# Altium schematic sheet Power Stage.SchDoc
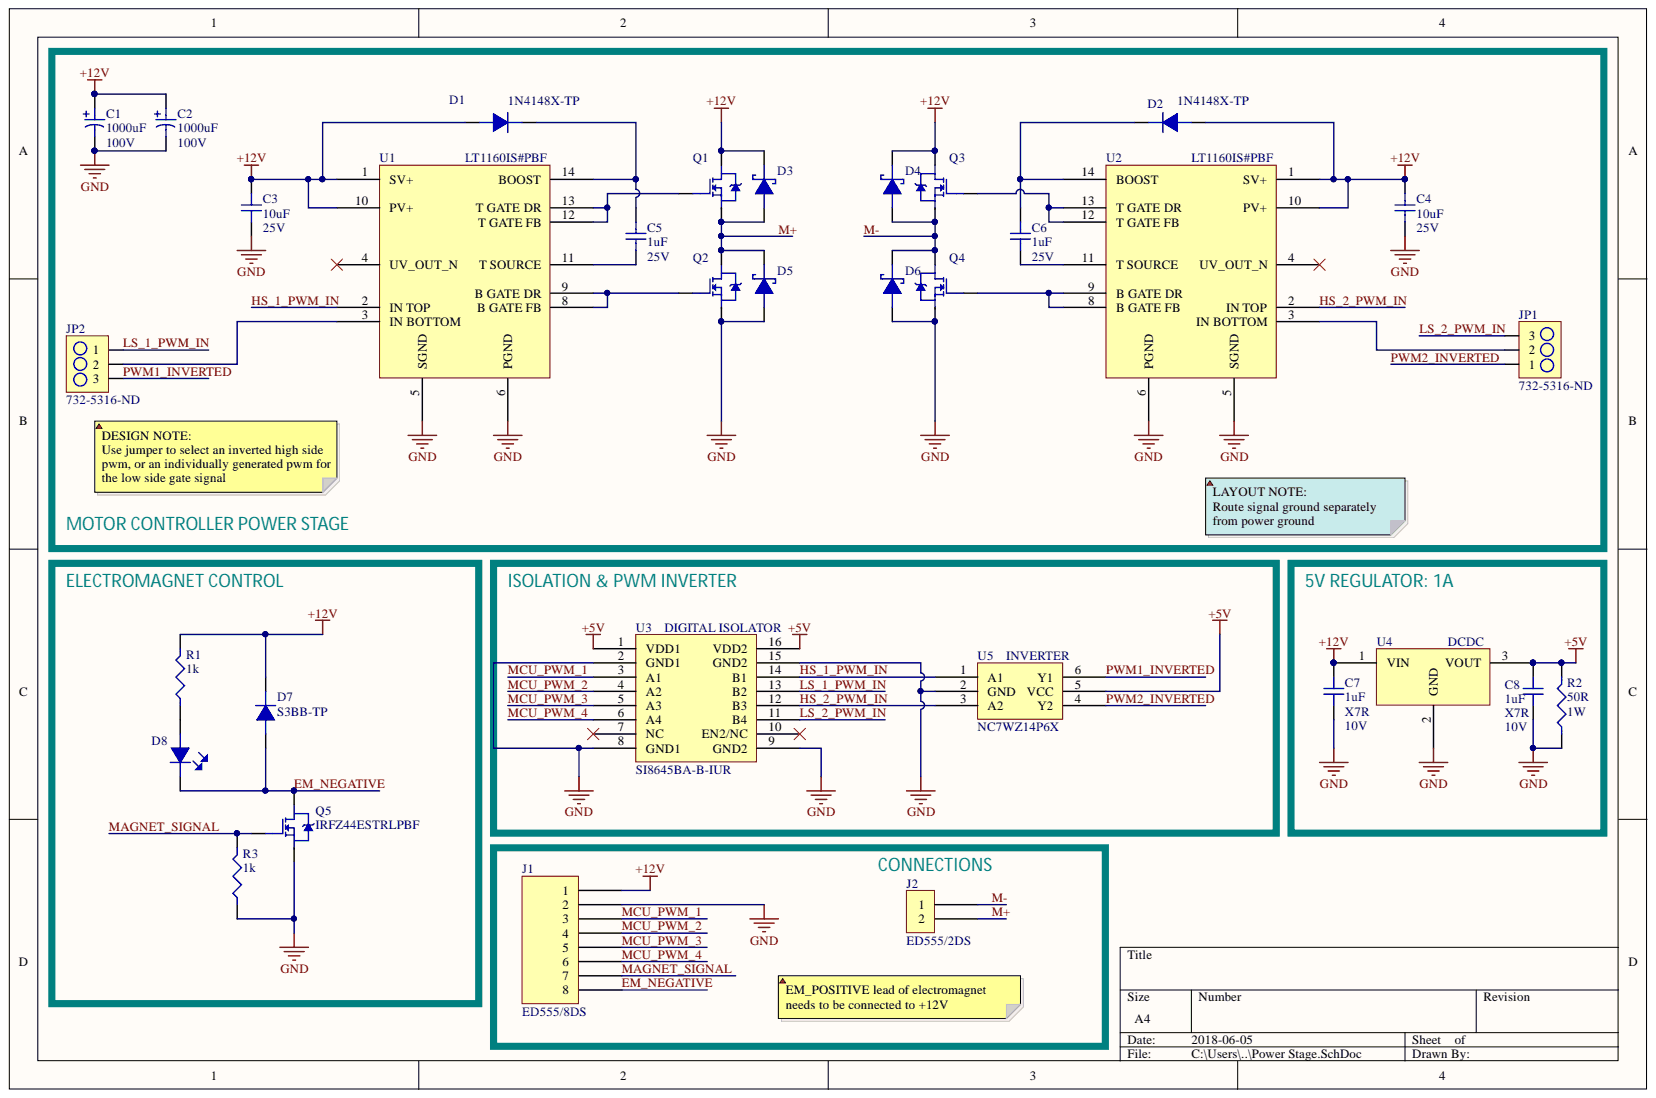
[diagram p0: Power Stage.SchDoc]

## Parts
C1  Capacitance: 1000uF  Voltage Rating: 100V  [CAP_THRU]  (hidden comment: UVR2A102MRD6)
C2  Capacitance: 1000uF  Voltage Rating: 100V  [CAP_THRU]  (hidden comment: UVR2A102MRD6)
C3  Capacitance: 10uF  Voltage Rating: 25V  [C1206]  (hidden comment: C3216X5R1E106K160AB)
C4  Capacitance: 10uF  Voltage Rating: 25V  [C1206]  (hidden comment: C3216X5R1E106K160AB)
C5  1uF  Voltage: 25V  [C0805]
C6  1uF  Voltage: 25V  [C0805]
C7  1uF  Dielectric: X7R  Voltage: 10V  [C0805]
C8  1uF  Dielectric: X7R  Voltage: 10V  [C0805]
D1  1N4148X-TP  [SOD523]
D2  1N4148X-TP  [SOD523]
D3  CDBA540-HF  [DO214-AC]  (value not drawn)
D4  CDBA540-HF  [DO214-AC]  (value not drawn)
D5  CDBA540-HF  [DO214-AC]  (value not drawn)
D6  CDBA540-HF  [DO214-AC]  (value not drawn)
D7  S3BB-TP  [DO-214AA_Diode]
D8  TST-C191TBKT-5A  [LED_0603]  (value not drawn)
J1  ED555/8DS  [8POS_CONNECTOR]
J2  ED555/2DS  [2POS_CONNECTOR]
JP1  732-5316-ND  [3PIN_HEADER]
JP2  732-5316-ND  [3PIN_HEADER]
Q1  IRFZ44ESTRLPBF  [D2PAK]
Q2  IRFZ44ESTRLPBF  [D2PAK]
Q3  IRFZ44ESTRLPBF  [D2PAK]
Q4  IRFZ44ESTRLPBF  [D2PAK]
Q5  IRFZ44ESTRLPBF  [D2PAK]
R1  1k  [R0805]
R2  50R  Max Power Dissipation: 1W  [R2512]
R3  1k  [R0805]
U1  LT1160IS#PBF  [SOIC-14]
U2  LT1160IS#PBF  [SOIC-14]
U3  SI8645BA-B-IUR  [QSOP-16]
U4  DCDC  [DCDC]
U5  NC7WZ14P6X  [TSOP-6]

## Nets
+5V: C8.2 R2.2 U3.1(VDD1) U3.16(VDD2) U4.3 U5.5(VCC)
+12V: C1.1 C2.1 C3.2 C4.2 C7.2 D1.1 D2.1 D3.2 D4.2 D7.2 J1.1 Q1.2 Q3.2 R1.2 U1.1(SV+) U1.10(PV+) U2.1(SV+) U2.10(PV+) U4.1
GND: C1.2 C2.2 C3.1 C4.1 C7.1 C8.1 D5.1 D6.1 J1.2 Q2.3 Q4.3 Q5.3 R2.1 R3.1 U1.5(SGND) U1.6(PGND) U2.5(SGND) U2.6(PGND) U3.2(GND1) U3.8(GND1) U3.9(GND2) U3.15(GND2) U4.2 U5.2(GND)
EM_NEGATIVE: D7.1 D8.2 J1.8 Q5.2
HS_1_PWM_IN: U1.2(IN_TOP) U3.14(B1) U5.1(A1)
HS_2_PWM_IN: U2.2(IN_TOP) U3.12(B3) U5.3(A2)
LS_1_PWM_IN: JP2.1 U3.13(B2)
LS_2_PWM_IN: JP1.3 U3.11(B4)
M+: D3.1 D5.2 J2.2 Q1.3 Q2.2
M-: D4.1 D6.2 J2.1 Q3.3 Q4.2
MAGNET_SIGNAL: J1.7 Q5.1 R3.2
MCU_PWM_1: J1.3 U3.3(A1)
MCU_PWM_2: J1.4 U3.4(A2)
MCU_PWM_3: J1.5 U3.5(A3)
MCU_PWM_4: J1.6 U3.6(A4)
PWM1_INVERTED: JP2.3 U5.6(Y1)
PWM2_INVERTED: JP1.1 U5.4(Y2)
NetC5_1: C5.1 U1.11(T_SOURCE)
NetC5_2: C5.2 D1.2 U1.14(BOOST)
NetC6_1: C6.1 U2.11(T_SOURCE)
NetC6_2: C6.2 D2.2 U2.14(BOOST)
NetD8_1: D8.1 R1.1
NetJP1_2: JP1.2 U2.3(IN_BOTTOM)
NetJP2_2: JP2.2 U1.3(IN_BOTTOM)
NetQ1_1: Q1.1 U1.12(T_GATE_FB) U1.13(T_GATE_DR)
NetQ2_1: Q2.1 U1.8(B_GATE_FB) U1.9(B_GATE_DR)
NetQ3_1: Q3.1 U2.12(T_GATE_FB) U2.13(T_GATE_DR)
NetQ4_1: Q4.1 U2.8(B_GATE_FB) U2.9(B_GATE_DR)

Not connected: U1 4(UV_OUT_N); U2 4(UV_OUT_N); U3 7(NC) 10(EN2/NC)

## Library data of the parts
C1 C2 = UVR2A102MRD6: CAP Electrolytic 1000uF 100V; Manufacturer: Nichicon; Dissipation Factor: 0.08%; Lead/Base Style: Straight; Life (Hours): 2000Hour; Polarity: Polar; Ripple Current: 1.38A; Tolerance: 20%; Case/Package: Radial; Component Kind: Standard; ComponentLink1Description: http://www.mouser.com/ds/2/293/e-uvr-1076495.pdf; ComponentLink1URL: http://www.mouser.com/ds/2/293/e-uvr-1076495.pdf; ComponentLink2Description: http://nichicon-us.com/english/products/pdfs/e-uvr.pdf; ComponentLink2URL: http://nichicon-us.com/english/products/pdfs/e-uvr.pdf; Lead Pitch: 7.5mm; Length: 35mm; Library Name: SCH_SYMBOLS.SchLib; Manufacturer Part Number: UVR2A102MRD6; Max Operating Temperature: 85°C; Min Operating Temperature: -40°C; Mount: Through Hole; Package Quantity: 50; Packaging: Bulk; Pricing 1: 1=1.84, 10=1.492, 100=1.1391, 500=0.8679, 1000=0.7594, 2500=0.7323, 5000=0.7052 (USD); Stock 1: 1438; Supplier 1: Digi-Key; Supplier Part Number 1: 493-14310-ND; Termination: Radial; Voltage Rating (DC): 100V
C3 C4 = C3216X5R1E106K160AB: CAP MLCC 10µF ±10% 25V X5R Ceramic Capacitor -55°C ~ 85°C Surface Mount, MLCC 1206 (3216 Metric) 0.126" L x 0.063" W (3.20mm x 1.60mm); Manufacturer: TDK; Case/Package: 1206; Case Code (Imperial): 1206; Case Code (Metric): 3216; Component Kind: Standard; ComponentLink1Description: https://datasheet.ciiva.com/6276/tdkmlcccaprange-6276583.pdf; ComponentLink1URL: https://datasheet.ciiva.com/6276/tdkmlcccaprange-6276583.pdf; ComponentLink2Description: https://datasheet.ciiva.com/17487/e412-c-17487288.pdf; ComponentLink2URL: https://datasheet.ciiva.com/17487/e412-c-17487288.pdf; ComponentLink3Description: https://datasheet.ciiva.com/24217/c3216x5r1e106k160ab-265309-24217784.pdf; ComponentLink3URL: https://datasheet.ciiva.com/24217/c3216x5r1e106k160ab-265309-24217784.pdf; ComponentLink4Description: https://datasheet.ciiva.com/6276/tdkmlcccaprange-6276585.pdf; ComponentLink4URL: https://datasheet.ciiva.com/6276/tdkmlcccaprange-6276585.pdf; ComponentLink5Description: https://datasheet.ciiva.com/6276/tdkmlcccaprange-6276587.pdf; ComponentLink5URL: https://datasheet.ciiva.com/6276/tdkmlcccaprange-6276587.pdf; Composition: Ceramic; Depth: 1.6mm; Dielectric: X5R; Dielectric Material: Ceramic; Height: 1.6mm; Lead Free: Lead Free; Length: 3.2mm; Library Name: SCH_SYMBOLS.SchLib; Manufacturer Part Number: C3216X5R1E106K160AB; Max Operating Temperature: 85°C; Min Operating Temperature: -55°C; Mount: Surface Mount; Number of Pins: 2; Package Quantity: 2000; Packaging: Tape and Reel; Pricing 1: 1=0.83, 10=0.589, 100=0.3881, 500=0.2875, 1000=0.2444 (USD); Radiation Hardening: No; Stock 1: 3130; Supplier 1: Digi-Key; Supplier Part Number 1: 445-6862-1-ND; Termination: SMD/SMT; Thickness: 0.075inch; Tolerance: 10%; Voltage Rating (DC): 25V; Weight: 0.000571oz; Width: 0.063inch
C5 C6 = GCM21BR71E105KA56L: CAP MLCC 1UF 25V X7R 0805; Manufacturer: Murata; Tolerance: 10%; Capacitance: 1uF; Case/Package: 0805; Case Code (Imperial): 0805; Case Code (Metric): 2012; Component Kind: Standard; ComponentLink1Description: https://datasheet.ciiva.com/137/product-137200.pdf; ComponentLink1URL: https://datasheet.ciiva.com/137/product-137200.pdf; ComponentLink2Description: https://datasheet.ciiva.com/3778/359gcm21br71e105ka5623-3778560.pdf; ComponentLink2URL: https://datasheet.ciiva.com/3778/359gcm21br71e105ka5623-3778560.pdf; ComponentLink3Description: https://datasheet.ciiva.com/16624/product-16624576.pdf; ComponentLink3URL: https://datasheet.ciiva.com/16624/product-16624576.pdf; ComponentLink4Description: https://datasheet.ciiva.com/18183/getdatasheetpartid-153106-18183696.pdf; ComponentLink4URL: https://datasheet.ciiva.com/18183/getdatasheetpartid-153106-18183696.pdf; ComponentLink5Description: https://datasheet.ciiva.com/137/product-137201.pdf; ComponentLink5URL: https://datasheet.ciiva.com/137/product-137201.pdf; ComponentLink6Description: https://datasheet.ciiva.com/11789/0900766b80ef92d0-11789092.pdf; ComponentLink6URL: https://datasheet.ciiva.com/11789/0900766b80ef92d0-11789092.pdf; ComponentLink7Description: https://datasheet.ciiva.com/2989/product-2989245.pdf; ComponentLink7URL: https://datasheet.ciiva.com/2989/product-2989245.pdf; ComponentLink8Description: https://datasheet.ciiva.com/2989/product-2989246.pdf; ComponentLink8URL: https://datasheet.ciiva.com/2989/product-2989246.pdf; ComponentLink9Description: https://datasheet.ciiva.com/137/product-137199.pdf; ComponentLink9URL: https://datasheet.ciiva.com/137/product-137199.pdf; Depth: 1.25mm; Dielectric: X7R; Height: 1.25mm; Lead Free: Lead Free; Length: 2mm; Library Name: SCH_SYMBOLS.SchLib; Manufacturer Part Number: GCM21BR71E105KA56L; Max Operating Temperature: 125°C; Min Operating Temperature: -55°C; Mount: Surface Mount; Package Quantity: 3000; Packaging: Tape and Reel; Pricing 1: 1=0.25, 10=0.171, 100=0.0865, 500=0.0592, 1000=0.0524 (USD); Radiation Hardening: No; REACH SVHC: No SVHC; Stock 1: 30000; Supplier 1: Digi-Key; Supplier Part Number 1: 490-4785-1-ND; Termination: SMD/SMT; Thickness: 0.055inch; Voltage Rating: 25V; Voltage Rating (DC): 25V; Width: 0.049inch
C7 C8 = 0805ZC105KAT2A: CAP CER 1UF 10V X7R 0805; Manufacturer: Kyocera AVX; Dissipation Factor: 5%; Insulation Resistance: 100GR; Tolerance: 10%; Capacitance: 1uF; Case/Package: 0805; Case Code (Imperial): 0805; Case Code (Metric): 2012; Component Kind: Standard; ComponentLink1Description: https://datasheet.ciiva.com/2988/cx7r-2988071.pdf; ComponentLink1URL: https://datasheet.ciiva.com/2988/cx7r-2988071.pdf; ComponentLink2Description: https://datasheet.ciiva.com/2988/cx7r-2988072.pdf; ComponentLink2URL: https://datasheet.ciiva.com/2988/cx7r-2988072.pdf; ComponentLink3Description: https://datasheet.ciiva.com/14601/70001075-14601048.pdf; ComponentLink3URL: https://datasheet.ciiva.com/14601/70001075-14601048.pdf; ComponentLink4Description: https://datasheet.ciiva.com/14594/70001075-14594329.pdf; ComponentLink4URL: https://datasheet.ciiva.com/14594/70001075-14594329.pdf; ComponentLink5Description: https://datasheet.ciiva.com/25901/cx7r-25901193.pdf; ComponentLink5URL: https://datasheet.ciiva.com/25901/cx7r-25901193.pdf; ComponentLink6Description: https://datasheet.ciiva.com/15165/getdatasheetpartid-71567-15165451.pdf; ComponentLink6URL: https://datasheet.ciiva.com/15165/getdatasheetpartid-71567-15165451.pdf; ComponentLink7Description: https://datasheet.ciiva.com/151/cx7r-151916.pdf; ComponentLink7URL: https://datasheet.ciiva.com/151/cx7r-151916.pdf; ComponentLink8Description: https://datasheet.ciiva.com/151/cx7r-151917.pdf; ComponentLink8URL: https://datasheet.ciiva.com/151/cx7r-151917.pdf; ComponentLink9Description: https://datasheet.ciiva.com/151/cx7r-151918.pdf; ComponentLink9URL: https://datasheet.ciiva.com/151/cx7r-151918.pdf; ComponentLink10Description: https://datasheet.ciiva.com/11787/0900766b80ed4be2-11787102.pdf; ComponentLink10URL: https://datasheet.ciiva.com/11787/0900766b80ed4be2-11787102.pdf; ComponentLink11Description: https://datasheet.ciiva.com/7812/84526-7812223.pdf; ComponentLink11URL: https://datasheet.ciiva.com/7812/84526-7812223.pdf; ComponentLink12Description: https://datasheet.ciiva.com/19475/2011248-19475855.pdf; ComponentLink12URL: https://datasheet.ciiva.com/19475/2011248-19475855.pdf; Contact Plating: Tin,Matte; Depth: 1.25mm; Height: 1.4mm; Lead Free: Lead Free; Length: 2.01mm; Library Name: SCH_SYMBOLS.SchLib; Manufacturer Part Number: 0805ZC105KAT2A; Max Operating Temperature: 125°C; Min Operating Temperature: -55°C; Mount: Surface Mount,PCB; Number of Pins: 2; Package Quantity: 1; Packaging: Tape and Reel; Pricing 1: 1=0.21, 10=0.147, 100=0.069, 500=0.0484, 1000=0.0401 (USD); Radiation Hardening: No; REACH SVHC: No SVHC; Stock 1: 385183; Supplier 1: Digi-Key; Supplier Part Number 1: 478-1405-1-ND; Termination: SMD/SMT; Thickness: 0.055inch; Voltage Rating: 10V; Voltage Rating (DC): 10V; Width: 1.25mm
D1 D2 = 1N4148X-TP: DIODE GEN PURP 75V 150MA SOD523; Manufacturer: MCC; Capacitance: 2pF; Element Configuration: Single; Forward Current: 300mA; Forward Voltage: 1.25V; Max Repetitive Reverse Voltage (Vrrm): 100V; Max Reverse Leakage Current: 1uA; Max Surge Current: 2A; Polarity: Standard; Power Dissipation: 200mW; Recovery Time: 4ns; Average Rectified Current: 150mA; Component Kind: Standard; ComponentLink1Description: https://datasheet.ciiva.com/911/1n4148xsod-523-911686.pdf; ComponentLink1URL: https://datasheet.ciiva.com/911/1n4148xsod-523-911686.pdf; ComponentLink2Description: https://datasheet.ciiva.com/4435/1n4148xsod-523-358-4435190.pdf; ComponentLink2URL: https://datasheet.ciiva.com/4435/1n4148xsod-523-358-4435190.pdf; ComponentLink3Description: https://datasheet.ciiva.com/911/1n4148xsod-523-911687.pdf; ComponentLink3URL: https://datasheet.ciiva.com/911/1n4148xsod-523-911687.pdf; ComponentLink4Description: https://datasheet.ciiva.com/911/1n4148xsod-523-911688.pdf; ComponentLink4URL: https://datasheet.ciiva.com/911/1n4148xsod-523-911688.pdf; ComponentLink5Description: https://datasheet.ciiva.com/16836/1n4148xsod-523-16836299.pdf; ComponentLink5URL: https://datasheet.ciiva.com/16836/1n4148xsod-523-16836299.pdf; Current Rating: 150mA; Lead Free: Lead Free; Library Name: SCH_SYMBOLS.SchLib; Manufacturer Part Number: 1N4148X-TP; Max Operating Temperature: 150°C; Max Reverse Voltage (DC): 75V; Min Operating Temperature: -65°C; Mount: Surface Mount; Number of Pins: 2; Output Current: 300mA; Package Quantity: 1; Packaging: Tape and Reel; Peak Non-Repetitive Surge Current: 2A; Pricing 1: 1=0.14, 10=0.131, 100=0.0856, 500=0.0419, 1000=0.0285 (USD); Radiation Hardening: No; Reverse Recovery Time: 4ns; Stock 1: 978848; Supplier 1: Digi-Key; Supplier Part Number 1: 1N4148XTPMSCT-ND; Voltage Rating (DC): 75V
D3-D6 = CDBA540-HF: DIODE Schottky Diodes & Rectifiers 40V, 5A; Manufacturer: Comchip; Average Rectified Current: 5A; Component Kind: Standard; ComponentLink1Description: http://www.mouser.com/ds/2/80/CDBA520-HF%20Thru134737.%20CDBA5200-HF%20RevB-221146.pdf; ComponentLink1URL: http://www.mouser.com/ds/2/80/CDBA520-HF%20Thru134737.%20CDBA5200-HF%20RevB-221146.pdf; ComponentLink2Description: http://www.comchiptech.com/cms/UserFiles/CDBA540-HF%20Thru505315.%20CDBA5200-HF%20RevC.pdf; ComponentLink2URL: http://www.comchiptech.com/cms/UserFiles/CDBA540-HF%20Thru505315.%20CDBA5200-HF%20RevC.pdf; Library Name: SCH_SYMBOLS.SchLib; Manufacturer Part Number: CDBA540-HF; Max Reverse Voltage (DC): 40V; Mount: Surface Mount; Packaging: Tape and Reel; Pricing 1: 1=0.49, 10=0.381, 100=0.2612, 500=0.1791, 1000=0.1343 (USD); Stock 1: 61079; Supplier 1: Digi-Key; Supplier Part Number 1: 641-1707-1-ND
D7 = S3BB-TP: DIODE GEN PURP 100V 3A DO214AA; Manufacturer: MCC; Element Configuration: Single; Forward Voltage: 1.15V; Max Surge Current: 100A; Peak Reverse Current: 10uA; Average Rectified Current: 3A; Component Kind: Standard; ComponentLink1Description: https://datasheet.ciiva.com/914/s3ab-s3mbsmb-914278.pdf; ComponentLink1URL: https://datasheet.ciiva.com/914/s3ab-s3mbsmb-914278.pdf; ComponentLink2Description: https://datasheet.ciiva.com/914/s3ab-s3mbsmb-914279.pdf; ComponentLink2URL: https://datasheet.ciiva.com/914/s3ab-s3mbsmb-914279.pdf; ComponentLink3Description: https://datasheet.ciiva.com/914/s3ab-s3mbsmb-914280.pdf; ComponentLink3URL: https://datasheet.ciiva.com/914/s3ab-s3mbsmb-914280.pdf; ComponentLink4Description: https://datasheet.ciiva.com/15200/getdatasheetpartid-686538-15200554.pdf; ComponentLink4URL: https://datasheet.ciiva.com/15200/getdatasheetpartid-686538-15200554.pdf; ComponentLink5Description: https://datasheet.ciiva.com/17437/s3ab-s3mbsmb-17437836.pdf; ComponentLink5URL: https://datasheet.ciiva.com/17437/s3ab-s3mbsmb-17437836.pdf; Lead Free: Lead Free; Library Name: SCH_SYMBOLS.SchLib; Manufacturer Part Number: S3BB-TP; Max Repetitive Reverse Voltage (Vrrm): 100V; Mount: Surface Mount; Number of Pins: 2; Package Quantity: 1; Packaging: Tape and Reel; Peak Non-Repetitive Surge Current: 100A; Pricing 1: 1=0.56, 10=0.441, 25=0.372, 100=0.3028, 250=0.2509, 500=0.2076, 1000=0.1557 (USD); Radiation Hardening: No; Reverse Voltage (DC): 100V; Stock 1: 10943; Supplier 1: Digi-Key; Supplier Part Number 1: S3BB-TPMSCT-ND
D8 = LTST-C191TBKT-5A: LED BLUE CLEAR 0603 SMD; Manufacturer: Vishay Lite-On; Dominant Wavelength: 473nm; Forward Current: 20mA; Forward Voltage: 2.8V; Lens Colour: Colorless; Lens Style: Diffused,Colorless,Rectangular; Luminous Intensity: 15mcd; Number of LEDs: 1; Test Current: 5mA; Viewing Angle: 130°; Voltage: 29V; Case/Package: 0603; Case Code (Imperial): 0603; Case Code (Metric): 1608; Colour: Blue; Component Kind: Standard; ComponentLink1Description: http://components.arrow.com/part/dataview/52218576S9813001N1331; ComponentLink1URL: http://components.arrow.com/part/dataview/52218576S9813001N1331; ComponentLink2Description: http://optoelectronics.liteon.com/zh-cn/api/DwonloadFileHandler.ashx?txtSpecNo=DS22-2003-020&txtPartNo=LTST-C191TBKT-5A; ComponentLink2URL: http://optoelectronics.liteon.com/zh-cn/api/DwonloadFileHandler.ashx?txtSpecNo=DS22-2003-020&txtPartNo=LTST-C191TBKT-5A; Height: 0.55mm; Illumination Colour: Blue; Length: 1.6mm; Lens Transparency: Clear; Library Name: SCH_SYMBOLS.SchLib; Manufacturer Part Number: LTST-C191TBKT-5A; Max Operating Temperature: 80°C; Min Operating Temperature: -20°C; Mount: Surface Mount; Package Quantity: 5000; Packaging: Tape and Reel; Pricing 1: 1=0.52, 10=0.376, 25=0.2884, 100=0.1881, 250=0.138, 500=0.117, 1000=0.0961, 2500=0.0836 (USD); Radiation Hardening: No; Stock 1: 2918; Supplier 1: Digi-Key; Supplier Part Number 1: 160-2212-6-ND; Width: 0.8mm
J1 = ED555/8DS: TERMINAL BLOCK 3.5MM 8POS PCB; Manufacturer: On-Shore Technology; Current: 6A; Number of Levels: 1; Number of Positions: 8; Pitch: 0.138inch; Plating: Steel; Voltage: 150V; Wire Gauge (Max): 28AWG; Wire Gauge (Min): 16AWG; Colour: Grey; Component Kind: Standard; ComponentLink1Description: https://datasheet.ciiva.com/823/ed555ds-823663.pdf; ComponentLink1URL: https://datasheet.ciiva.com/823/ed555ds-823663.pdf; Contact Material: Brass; Contact Plating: Brass; Flammability Rating: UL94 V-0; Height: 8.2mm; Housing Colour: Grey; Housing Material: Nylon; Lead Free: Contains Lead; Length: 28mm; Library Name: SCH_SYMBOLS.SchLib; Manufacturer Part Number: ED555/8DS; Max Operating Temperature: 105°C; Min Operating Temperature: -30°C; Mount: Through Hole; Number of Pins: 8; Number of Positions Per Level: 8; Number of Rows: 1; Packaging: Bulk; Pricing 1: 1=2.74, 10=2.076, 25=1.9244, 50=1.7302, 100=1.688, 250=1.477, 500=1.3504, 1000=1.2238, 5000=1.0761 (USD); Stock 1: 4606; Supplier 1: Digi-Key; Supplier Part Number 1: ED1520-ND; Termination: Screw; Width: 6.2mm
J2 = ED555/2DS: TERMINAL BLOCK 3.5MM 2POS PCB; Manufacturer: On-Shore Technology; Current: 6A; Number of Levels: 1; Number of Positions: 2; Pitch: 0.138inch; Plating: Steel; Voltage: 150V; Wire Gauge (Max): 28AWG; Wire Gauge (Min): 16AWG; Colour: Grey; Component Kind: Standard; ComponentLink1Description: https://datasheet.ciiva.com/823/ed555ds-823583.pdf; ComponentLink1URL: https://datasheet.ciiva.com/823/ed555ds-823583.pdf; Contact Material: Brass; Contact Plating: Brass; Flammability Rating: UL94 V-0; Height: 8.2mm; Housing Colour: Grey; Housing Material: Nylon; Lead Free: Lead Free; Length: 7mm; Library Name: SCH_SYMBOLS.SchLib; Manufacturer Part Number: ED555/2DS; Max Operating Temperature: 105°C; Min Operating Temperature: -30°C; Mount: Through Hole; Number of Pins: 2; Number of Positions Per Level: 2; Number of Rows: 1; Packaging: Bulk; Pricing 1: 1=1.06, 10=0.658, 25=0.5632, 50=0.5064, 100=0.4959, 250=0.422, 500=0.4009, 1000=0.3587, 5000=0.2806 (USD); Stock 1: 38938; Supplier 1: Digi-Key; Supplier Part Number 1: ED1514-ND; Termination: Screw; Width: 6.2mm
JP1 JP2 = 61300311121: CONN_HeaderPins3pos WURTH ELEKTRONIK Board-To-Board Connector, Vertical, WR-PHD Series, Through Hole, Header, 3 Contacts, 2.54 mm; Manufacturer: Wurth Electronics; Connector Type: Male Pin; Gender: Male; Number of Contacts: 3; Pitch: 0.1inch; Case/Package: 100; Colour: Black; Component Kind: Standard; ComponentLink1Description: http://www.farnell.com/cad/1737268.pdf; ComponentLink1URL: http://www.farnell.com/cad/1737268.pdf; Contact Material: Copper; Contact Mating Length: 0.236inch; Contact Plating: Gold; Lead Free: Lead Free; Library Name: SCH_SYMBOLS.SchLib; Manufacturer Part Number: 61300311121; Mount: Through Hole; Number of Positions: 3; Number of Rows: 1; Packaging: Bulk; Pricing 1: 1=0.13, 10=0.106, 25=0.088, 50=0.074, 100=0.067, 1000=0.061 (USD); REACH SVHC: Unknown; Stock 1: 57026; Supplier 1: Digi-Key; Supplier Part Number 1: 732-5316-ND; Termination: Solder
Q1-Q5 = IRFZ44ESTRLPBF: MOSFET N-CH 60V 48A D2PAK; Manufacturer: Infineon; Drain to Source Resistance: 23mR; Gate to Source Voltage (Vgs): 20V; Input Capacitance: 1.36nF; Max Power Dissipation: 110W; Rise Time: 60ns; Turn-Off Delay Time: 70ns; Turn-On Delay Time: 12ns; Case/Package: TO-263-3; Component Kind: Standard; ComponentLink1Description: https://www.alliedelec.com/m/d/1b68331d905676b420cb8944ec9d0bc2.pdf; ComponentLink1URL: https://www.alliedelec.com/m/d/1b68331d905676b420cb8944ec9d0bc2.pdf; ComponentLink2Description: http://www.infineon.com/dgdl/irfz44espbf.pdf?fileId=5546d462533600a40153563b2c662208; ComponentLink2URL: http://www.infineon.com/dgdl/irfz44espbf.pdf?fileId=5546d462533600a40153563b2c662208; ComponentLink3Description: http://www.irf.com/product-info/datasheets/data/irfz44espbf.pdf; ComponentLink3URL: http://www.irf.com/product-info/datasheets/data/irfz44espbf.pdf; Contact Plating: Tin,Matte; Continuous Drain Current (ID): 48A; Drain to Source Breakdown Voltage: 60V; Drain to Source Voltage (Vdss): 60V; Height: 0.19inch; Lead Free: Lead Free; Length: 0.42inch; Library Name: SCH_SYMBOLS.SchLib; Manufacturer Part Number: IRFZ44ESTRLPBF; Max Operating Temperature: 175°C; Min Operating Temperature: -55°C; Mount: Surface Mount; Number of Pins: 3; Packaging: Tape and Reel; Power Dissipation: 110W; Pricing 1: 1=1.61, 10=1.43, 100=1.1304 (USD); Rds On Max: 23mR; Stock 1: 600; Supplier 1: Digi-Key; Supplier Part Number 1: IRFZ44ESTRLPBFCT-ND; Width: 0.38inch
R1 R3 = ERA-6AEB102V: RES SMD 1K OHM 0805 1K Ohm 0.1% 0.125W(1/8W) ±25ppm/C Molded SMD Automotive Punched T/R; Manufacturer: Panasonic ECG; Max Power Dissipation: 125mW; Resistance: 1kR; Temperature Coefficient: 25ppm/°C; Voltage Rating: 100V; Case/Package: 0805; Case Code (Imperial): 0805; Case Code (Metric): 2012; Component Kind: Standard; ComponentLink1Description: https://datasheet.ciiva.com/11811/0900766b80beefcc-11811155.pdf; ComponentLink1URL: https://datasheet.ciiva.com/11811/0900766b80beefcc-11811155.pdf; ComponentLink2Description: https://datasheet.ciiva.com/30057/54aoa0000ce26-30057591.pdf; ComponentLink2URL: https://datasheet.ciiva.com/30057/54aoa0000ce26-30057591.pdf; ComponentLink3Description: https://datasheet.ciiva.com/48536/era-eng-tds-48536727.pdf; ComponentLink3URL: https://datasheet.ciiva.com/48536/era-eng-tds-48536727.pdf; ComponentLink4Description: https://datasheet.ciiva.com/9198/aoa0000ce26-9198984.pdf; ComponentLink4URL: https://datasheet.ciiva.com/9198/aoa0000ce26-9198984.pdf; ComponentLink5Description: https://datasheet.ciiva.com/16782/70068849-16782808.pdf; ComponentLink5URL: https://datasheet.ciiva.com/16782/70068849-16782808.pdf; ComponentLink6Description: https://datasheet.ciiva.com/24025/aoa0000ce26-197354-24025849.pdf; ComponentLink6URL: https://datasheet.ciiva.com/24025/aoa0000ce26-197354-24025849.pdf; ComponentLink7Description: https://datasheet.ciiva.com/43455/485442-43455257.pdf; ComponentLink7URL: https://datasheet.ciiva.com/43455/485442-43455257.pdf; ComponentLink8Description: https://datasheet.ciiva.com/43457/485442-43457803.pdf; ComponentLink8URL: https://datasheet.ciiva.com/43457/485442-43457803.pdf; ComponentLink9Description: https://datasheet.ciiva.com/7942/485442-7942414.pdf; ComponentLink9URL: https://datasheet.ciiva.com/7942/485442-7942414.pdf; ComponentLink10Description: https://datasheet.ciiva.com/16201/aoa0000ce26-16201486.pdf; ComponentLink10URL: https://datasheet.ciiva.com/16201/aoa0000ce26-16201486.pdf; ComponentLink11Description: https://datasheet.ciiva.com/22783/485442-22783806.pdf; ComponentLink11URL: https://datasheet.ciiva.com/22783/485442-22783806.pdf; ComponentLink12Description: https://datasheet.ciiva.com/7942/485442-7942415.pdf; ComponentLink12URL: https://datasheet.ciiva.com/7942/485442-7942415.pdf; ComponentLink13Description: https://datasheet.ciiva.com/29184/453027-da-01-en-widerstand-0805-era-29184108.pdf; ComponentLink13URL: https://datasheet.ciiva.com/29184/453027-da-01-en-widerstand-0805-era-29184108.pdf; Composition: Thin Film; Contact Plating: Tin; Depth: 1.25mm; Height: 0.5mm; Length: 2mm; Library Name: SCH_SYMBOLS.SchLib; Manufacturer Part Number: ERA-6AEB102V; Max Operating Temperature: 155°C; Military Standard: Not; Min Operating Temperature: -55°C; Mount: Surface Mount; Number of Pins: 2; Number of Terminations: 2; Package Quantity: 5000; Packaging: Tape and Reel; Power Rating: 125mW; Pricing 1: 1=0.36, 10=0.302, 100=0.1182, 1000=0.0495, 2500=0.0454 (USD); Radiation Hardening: No; REACH SVHC: No SVHC; Stock 1: 506583; Supplier 1: Digi-Key; Supplier Part Number 1: P1.0KDACT-ND; Termination: SMD/SMT; Tolerance: 0.1%; Width: 1.25mm
R2 = WSC251550R00FEA: RES SMD 50 OHM 1% 1W 2515; Manufacturer: Vishay Dale; Temperature Coefficient: 20ppm/°C; Voltage Rating: 7.1V; Voltage Rating (DC): 7.07V; Case/Package: 2515; Case Code (Imperial): 2515; Case Code (Metric): 6438; Component Kind: Standard; ComponentLink1Description: https://datasheet.ciiva.com/2484/wscwsn-2484608.pdf; ComponentLink1URL: https://datasheet.ciiva.com/2484/wscwsn-2484608.pdf; ComponentLink2Description: https://datasheet.ciiva.com/2484/wscwsn-2484609.pdf; ComponentLink2URL: https://datasheet.ciiva.com/2484/wscwsn-2484609.pdf; ComponentLink3Description: https://datasheet.ciiva.com/11812/0900766b80d9a4e3-11812404.pdf; ComponentLink3URL: https://datasheet.ciiva.com/11812/0900766b80d9a4e3-11812404.pdf; ComponentLink4Description: https://datasheet.ciiva.com/7702/wscwsn-7702439.pdf; ComponentLink4URL: https://datasheet.ciiva.com/7702/wscwsn-7702439.pdf; ComponentLink5Description: https://datasheet.ciiva.com/16510/wscwsn-16510183.pdf; ComponentLink5URL: https://datasheet.ciiva.com/16510/wscwsn-16510183.pdf; ComponentLink6Description: https://datasheet.ciiva.com/9002/wscwsn-9002776.pdf; ComponentLink6URL: https://datasheet.ciiva.com/9002/wscwsn-9002776.pdf; ComponentLink7Description: https://datasheet.ciiva.com/22696/1911141-22696987.pdf; ComponentLink7URL: https://datasheet.ciiva.com/22696/1911141-22696987.pdf; ComponentLink8Description: https://datasheet.ciiva.com/28658/1748041-28658109.pdf; ComponentLink8URL: https://datasheet.ciiva.com/28658/1748041-28658109.pdf; ComponentLink9Description: https://datasheet.ciiva.com/16513/wscwsn-16513037.pdf; ComponentLink9URL: https://datasheet.ciiva.com/16513/wscwsn-16513037.pdf; ComponentLink10Description: https://datasheet.ciiva.com/2484/wscwsn-2484607.pdf; ComponentLink10URL: https://datasheet.ciiva.com/2484/wscwsn-2484607.pdf; Composition: Wirewound; Contact Plating: Tin,Matte; Depth: 3.81mm; Height: 0.125inch; Lead Free: Lead Free; Length: 6.35mm; Library Name: SCH_SYMBOLS.SchLib; Manufacturer Part Number: WSC251550R00FEA; Max Operating Temperature: 275°C; Military Standard: Not; Min Operating Temperature: -65°C; Number of Terminations: 2; Package Quantity: 1; Packaging: Tape and Reel; Power Rating: 1W; Pricing 1: 1=1.67, 10=1.482, 25=1.3744, 50=1.2936, 100=1.1319, 250=0.9702, 500=0.8085, 1000=0.7007 (USD); Radiation Hardening: No; REACH SVHC: No SVHC; Resistance: 50R; Stock 1: 8237; Supplier 1: Digi-Key; Supplier Part Number 1: WSCB-50CT-ND; Tolerance: 1%; Width: 0.15inch
U1 U2 = LT1160IS#PBF: IC PWR MOSFET DRIVER N-CH 14SOIC; Manufacturer: Analog Devices / Linear Technology; Fall Time: 140ns; Max Output Current: 1.5A; Max Supply Voltage: 15V; Min Supply Voltage: 10V; Number of Drivers: 2; Operating Supply Voltage: 12V; Rise Time: 200ns; Turn-Off Delay Time: 600ns; Turn-On Delay Time: 500ns; Case/Package: S; Component Kind: Standard; ComponentLink1Description: https://datasheet.ciiva.com/1951/1303-1951985.pdf; ComponentLink1URL: https://datasheet.ciiva.com/1951/1303-1951985.pdf; ComponentLink2Description: https://datasheet.ciiva.com/15110/getdatasheetpartid-365202-15110225.pdf; ComponentLink2URL: https://datasheet.ciiva.com/15110/getdatasheetpartid-365202-15110225.pdf; Lead Free: Lead Free; Library Name: SCH_SYMBOLS.SchLib; Manufacturer Part Number: LT1160IS#PBF; Max Operating Temperature: 85°C; Min Operating Temperature: -40°C; Number of Outputs: 2; Number of Pins: 14; Package Quantity: 55; Packaging: Rail/Tube; Pricing 1: 1=6.66, 25=4.4244, 100=3.774 (USD); Radiation Hardening: No; Stock 1: 838; Supplier 1: Digi-Key; Supplier Part Number 1: LT1160IS#PBF-ND
U3 = SI8645BA-B-IUR: IC DIGITAL ISOLATOR 4CHANNEL 150MBPS 1000VRMS ISOLATION NO ISOLATED POWER; Manufacturer: Silicon Labs; Channel Type: Unidirectional; Number of Channels: 4; Propagation Delay: 13ns; Pulse Width Distortion (PWD): 4.5ns; Case/Package: SSOP; Component Kind: Standard; ComponentLink1Description: https://datasheet.ciiva.com/8124/si864x-8124729.pdf; ComponentLink1URL: https://datasheet.ciiva.com/8124/si864x-8124729.pdf; ComponentLink2Description: https://datasheet.ciiva.com/15272/si864x-15272845.pdf; ComponentLink2URL: https://datasheet.ciiva.com/15272/si864x-15272845.pdf; Data Rate: 150Mbps; Isolated Power: No; Library Name: SCH_SYMBOLS.SchLib; Manufacturer Part Number: SI8645BA-B-IUR; Max Operating Temperature: 125°C; Min Operating Temperature: -40°C; Number of Pins: 16; Package Quantity: 2500; Packaging: Tape and Reel; Pricing 1: 1=2.51, 25=2.4208, 100=2.3278 (USD); Stock 1: 2500; Supplier 1: Digi-Key; Supplier Part Number 1: SI8645BA-B-IURCT-ND; Type: General Purpose; Weight: 0.002931oz
U4 = R-78E5.0-1.0: Non-Isolated DC/DC Converters 8-28Vin 5V 1.0A THRU; Manufacturer: Recom; Current - Output 1: 1A; Efficiency: 91%; Max Input Voltage: 28V; Max Power Dissipation: 5W; Min Input Voltage: 8V; Number of Outputs: 1; Case/Package: SIP; Component Kind: Standard; ComponentLink1Description: http://www.farnell.com/datasheets/2290573.pdf; ComponentLink1URL: http://www.farnell.com/datasheets/2290573.pdf; ComponentLink2Description: http://www.mouser.com/ds/2/468/R-78Exx-1.0-958279.pdf; ComponentLink2URL: http://www.mouser.com/ds/2/468/R-78Exx-1.0-958279.pdf; ComponentLink3Description: https://www.recom-power.com/pdf/Innoline/R-78Exx-1.0.pdf; ComponentLink3URL: https://www.recom-power.com/pdf/Innoline/R-78Exx-1.0.pdf; Height: 10.4mm; Lead Free: Lead Free; Length: 11.6mm; Library Name: SCH_SYMBOLS.SchLib; Manufacturer Part Number: R-78E5.0-1.0; Max Operating Temperature: 85°C; Max Output Current: 1A; Max Output Voltage: 5V; Min Operating Temperature: -40°C; Mount: Through Hole; Output Power: 5W; Package Quantity: 42; Packaging: Rail/Tube; Pricing 1: 1=3.26, 5=3.208, 10=3.162, 25=3.0692, 50=2.976, 100=2.883, 250=2.79, 500=2.604 (USD); Stock 1: 12508; Supplier 1: Digi-Key; Supplier Part Number 1: 945-2201-ND; Voltage - Output 1: 5V; Weight: 2g; Width: 8.5mm
U5 = NC7WZ14P6X: IC INVERTER DUAL SCHMITT SC70-6; Manufacturer: ON Semiconductor / Fairchild; High Level Output Current: -32mA; Logic Function: Schmitt Trigger,Buffer,Inverter; Low Level Output Current: 32mA; Max Supply Voltage: 5.5V; Min Supply Voltage: 1.65V; Number of Circuits: 2; Number of Inputs: 1; Propagation Delay: 4.9ns; Quiescent Current: 1uA; Schmitt Trigger Input: Yes; Turn-On Delay Time: 14.5ns; Case/Package: SC; Component Kind: Standard; ComponentLink1Description: https://datasheet.ciiva.com/4827/nc7wz14-116143-4827508.pdf; ComponentLink1URL: https://datasheet.ciiva.com/4827/nc7wz14-116143-4827508.pdf; ComponentLink2Description: https://datasheet.ciiva.com/28416/1725703-28416486.pdf; ComponentLink2URL: https://datasheet.ciiva.com/28416/1725703-28416486.pdf; ComponentLink3Description: https://datasheet.ciiva.com/34301/874592-34301639.pdf; ComponentLink3URL: https://datasheet.ciiva.com/34301/874592-34301639.pdf; ComponentLink4Description: https://datasheet.ciiva.com/1861/nc7wz14-1861995.pdf; ComponentLink4URL: https://datasheet.ciiva.com/1861/nc7wz14-1861995.pdf; ComponentLink5Description: https://datasheet.ciiva.com/1861/nc7wz14-1861996.pdf; ComponentLink5URL: https://datasheet.ciiva.com/1861/nc7wz14-1861996.pdf; ComponentLink6Description: https://datasheet.ciiva.com/8248/nc7wz14-8248844.pdf; ComponentLink6URL: https://datasheet.ciiva.com/8248/nc7wz14-8248844.pdf; ComponentLink7Description: https://datasheet.ciiva.com/1861/nc7wz14-1861997.pdf; ComponentLink7URL: https://datasheet.ciiva.com/1861/nc7wz14-1861997.pdf; ComponentLink8Description: https://datasheet.ciiva.com/15089/getdatasheetpartid-110323-15089229.pdf; ComponentLink8URL: https://datasheet.ciiva.com/15089/getdatasheetpartid-110323-15089229.pdf; ComponentLink9Description: https://datasheet.ciiva.com/8248/nc7wz14-8248846.pdf; ComponentLink9URL: https://datasheet.ciiva.com/8248/nc7wz14-8248846.pdf; ComponentLink10Description: https://datasheet.ciiva.com/25628/0900766b80d1df42-25628686.pdf; ComponentLink10URL: https://datasheet.ciiva.com/25628/0900766b80d1df42-25628686.pdf; Contact Plating: Tin,Matte; Height: 1mm; Lead Free: Lead Free; Length: 2mm; Library Name: SCH_SYMBOLS.SchLib; Manufacturer Part Number: NC7WZ14P6X; Max Operating Temperature: 85°C; Min Operating Temperature: -40°C; Mount: Surface Mount; Number of Elements: 2; Number of Gates: 2; Number of Pins: 6; Output Current: 32mA; Package Quantity: 3000; Packaging: Tape and Reel; Radiation Hardening: No; REACH SVHC: No SVHC; Stock 1: 0; Supplier 1: Digi-Key; Supplier Part Number 1: NC7WZ14P6XTR-ND; Weight: 0.028g; Width: 1.25mm
